# Altium schematic sheet [17]-ETH_CONNECTOR.SchDoc([17]-ETH_CONNECTOR)
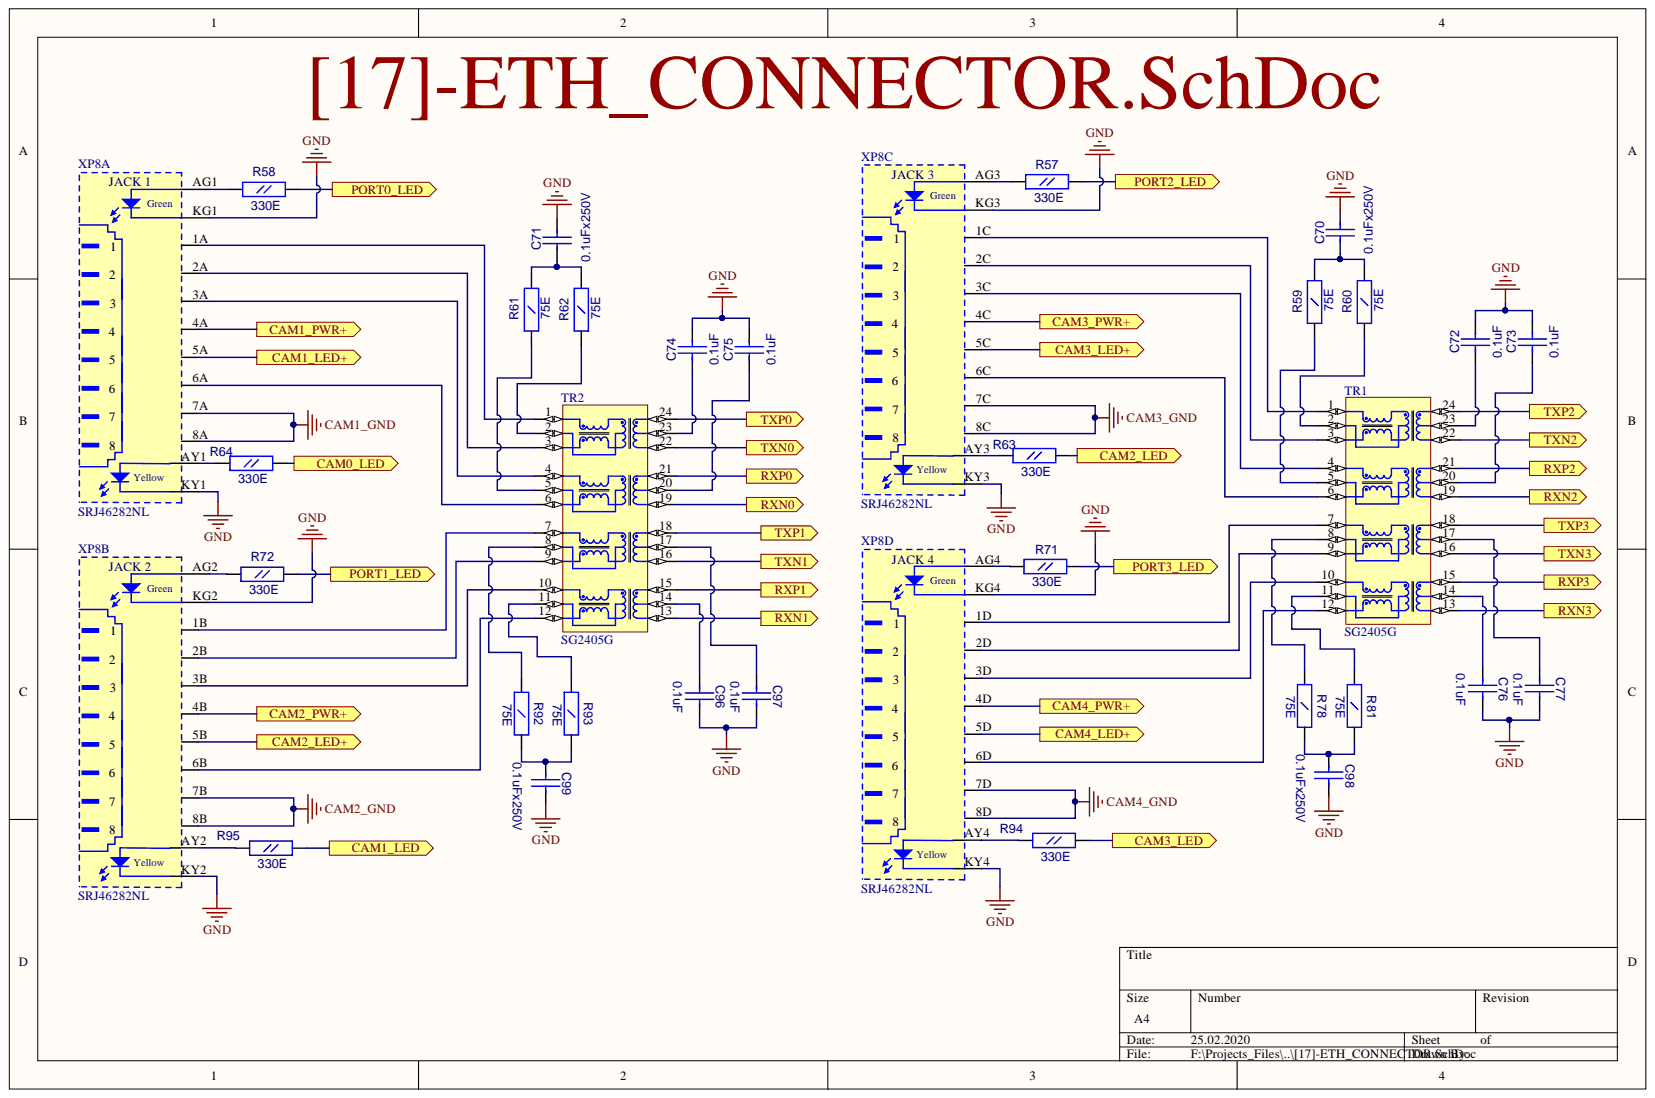
[diagram p0: [17]-ETH_CONNECTOR.SchDoc]

## Parts
C70  0.1uFx250V  [CC1206]
C71  0.1uFx250V  [CC1206]
C72  0.1uF  [CC0603]
C73  0.1uF  [CC0603]
C74  0.1uF  [CC0603]
C75  0.1uF  [CC0603]
C76  0.1uF  [CC0603]
C77  0.1uF  [CC0603]
C96  0.1uF  [CC0603]
C97  0.1uF  [CC0603]
C98  0.1uFx250V  [CC1206]
C99  0.1uFx250V  [CC1206]
R57  330E  [RC0603]
R58  330E  [RC0603]
R59  75E  [RC1206]
R60  75E  [RC1206]
R61  75E  [RC1206]
R62  75E  [RC1206]
R63  330E  [RC0603]
R64  330E  [RC0603]
R71  330E  [RC0603]
R72  330E  [RC0603]
R78  75E  [RC1206]
R81  75E  [RC1206]
R92  75E  [RC1206]
R93  75E  [RC1206]
R94  330E  [RC0603]
R95  330E  [RC0603]
TR1  SG2405G  [SG2405G]
TR2  SG2405G  [SG2405G]
XP8  SRJ46282NL  [SRJ46282NL]  (parts A,B,C,D)

## Ports
CAM0_LED, CAM1_LED, CAM1_LED+, CAM1_PWR+, CAM2_LED, CAM2_LED+, CAM2_PWR+, CAM3_LED, CAM3_LED+, CAM3_PWR+, CAM4_LED+, CAM4_PWR+, PORT0_LED, PORT1_LED, PORT2_LED, PORT3_LED, RXN0, RXN1, RXN2, RXN3, RXP0, RXP1, RXP2, RXP3, TXN0, TXN1, TXN2, TXN3, TXP0, TXP1, TXP2, TXP3

## Nets
CAM1_GND: XP8.7A XP8.8A
CAM2_GND: XP8.7B XP8.8B
CAM3_GND: XP8.7C XP8.8C
CAM4_GND: XP8.7D XP8.8D
GND: C70.2 C71.2 C72.2 C73.2 C74.2 C75.2 C76.2 C77.2 C96.2 C97.2 C98.2 C99.2 XP8.KG1 XP8.KG2 XP8.KG3 XP8.KG4 XP8.KY1 XP8.KY2 XP8.KY3 XP8.KY4
CAM0_LED: R64.2 ; port CAM0_LED
CAM1_LED: R95.2 ; port CAM1_LED
CAM1_LED+: XP8.5A ; port CAM1_LED+
CAM1_PWR+: XP8.4A ; port CAM1_PWR+
CAM2_LED: R63.2 ; port CAM2_LED
CAM2_LED+: XP8.5B ; port CAM2_LED+
CAM2_PWR+: XP8.4B ; port CAM2_PWR+
CAM3_LED: R94.2 ; port CAM3_LED
CAM3_LED+: XP8.5C ; port CAM3_LED+
CAM3_PWR+: XP8.4C ; port CAM3_PWR+
CAM4_LED+: XP8.5D ; port CAM4_LED+
CAM4_PWR+: XP8.4D ; port CAM4_PWR+
PORT0_LED: R58.2 ; port PORT0_LED
PORT1_LED: R72.2 ; port PORT1_LED
PORT2_LED: R57.2 ; port PORT2_LED
PORT3_LED: R71.2 ; port PORT3_LED
RXN0: TR2.19 ; port RXN0
RXN1: TR2.13 ; port RXN1
RXN2: TR1.19 ; port RXN2
RXN3: TR1.13 ; port RXN3
RXP0: TR2.21 ; port RXP0
RXP1: TR2.15 ; port RXP1
RXP2: TR1.21 ; port RXP2
RXP3: TR1.15 ; port RXP3
TXN0: TR2.22 ; port TXN0
TXN1: TR2.16 ; port TXN1
TXN2: TR1.22 ; port TXN2
TXN3: TR1.16 ; port TXN3
TXP0: TR2.24 ; port TXP0
TXP1: TR2.18 ; port TXP1
TXP2: TR1.24 ; port TXP2
TXP3: TR1.18 ; port TXP3
NetC70_1: C70.1 R59.2 R60.2
NetC71_1: C71.1 R61.2 R62.2
NetC72_1: C72.1 TR1.23
NetC73_1: C73.1 TR1.20
NetC74_1: C74.1 TR2.23
NetC75_1: C75.1 TR2.20
NetC76_1: C76.1 TR1.14
NetC77_1: C77.1 TR1.17
NetC96_1: C96.1 TR2.14
NetC97_1: C97.1 TR2.17
NetC98_1: C98.1 R78.2 R81.2
NetC99_1: C99.1 R92.2 R93.2
NetR57_1: R57.1 XP8.AG3
NetR58_1: R58.1 XP8.AG1
NetR59_1: R59.1 TR1.5
NetR60_1: R60.1 TR1.2
NetR61_1: R61.1 TR2.5
NetR62_1: R62.1 TR2.2
NetR63_1: R63.1 XP8.AY3
NetR64_1: R64.1 XP8.AY1
NetR71_1: R71.1 XP8.AG4
NetR72_1: R72.1 XP8.AG2
NetR78_1: R78.1 TR1.8
NetR81_1: R81.1 TR1.11
NetR92_1: R92.1 TR2.8
NetR93_1: R93.1 TR2.11
NetR94_1: R94.1 XP8.AY4
NetR95_1: R95.1 XP8.AY2
NetTR1_1: TR1.1 XP8.1C
NetTR1_3: TR1.3 XP8.2C
NetTR1_4: TR1.4 XP8.3C
NetTR1_6: TR1.6 XP8.6C
NetTR1_7: TR1.7 XP8.1D
NetTR1_9: TR1.9 XP8.2D
NetTR1_10: TR1.10 XP8.3D
NetTR1_12: TR1.12 XP8.6D
NetTR2_1: TR2.1 XP8.1A
NetTR2_3: TR2.3 XP8.2A
NetTR2_4: TR2.4 XP8.3A
NetTR2_6: TR2.6 XP8.6A
NetTR2_7: TR2.7 XP8.1B
NetTR2_9: TR2.9 XP8.2B
NetTR2_10: TR2.10 XP8.3B
NetTR2_12: TR2.12 XP8.6B

## Library data of the parts
C70 C71 C98 C99 = CC1206: Value: 0.1uFx250V; Description: CC1206; Component Kind: Standard; Library Name: fizik_lib (1).SchLib
C72-C77 C96 C97 = CC0603: Value: 0.1uF; Description: CC0603; Component Kind: Standard; Library Name: fizik_lib.SchLib
R57 R58 R63 R64 R71 R72 R94 R95 = RC0603: Value: 330E; Description: RC0603; Component Kind: Standard; Library Name: fizik_lib.SchLib
R59-R62 R78 R81 R92 R93 = RC1206: Value: 75E; Description: RC1206; Component Kind: Standard; Library Name: fizik_lib (1).SchLib
TR1 TR2 = SG2405G: Ehternet transformer Gigabit or 2 LAN10/100; Value: SG2405G; Component Kind: Standard; Library Name: fizik_lib (1).SchLib
XP8 = SRJ46282NL: Value: SRJ46282NL; Component Kind: Standard; Library Name: fizik_lib (1).SchLib
